# Altium schematic sheet boost_converter_var2.SchDoc(boost_converter_var2)
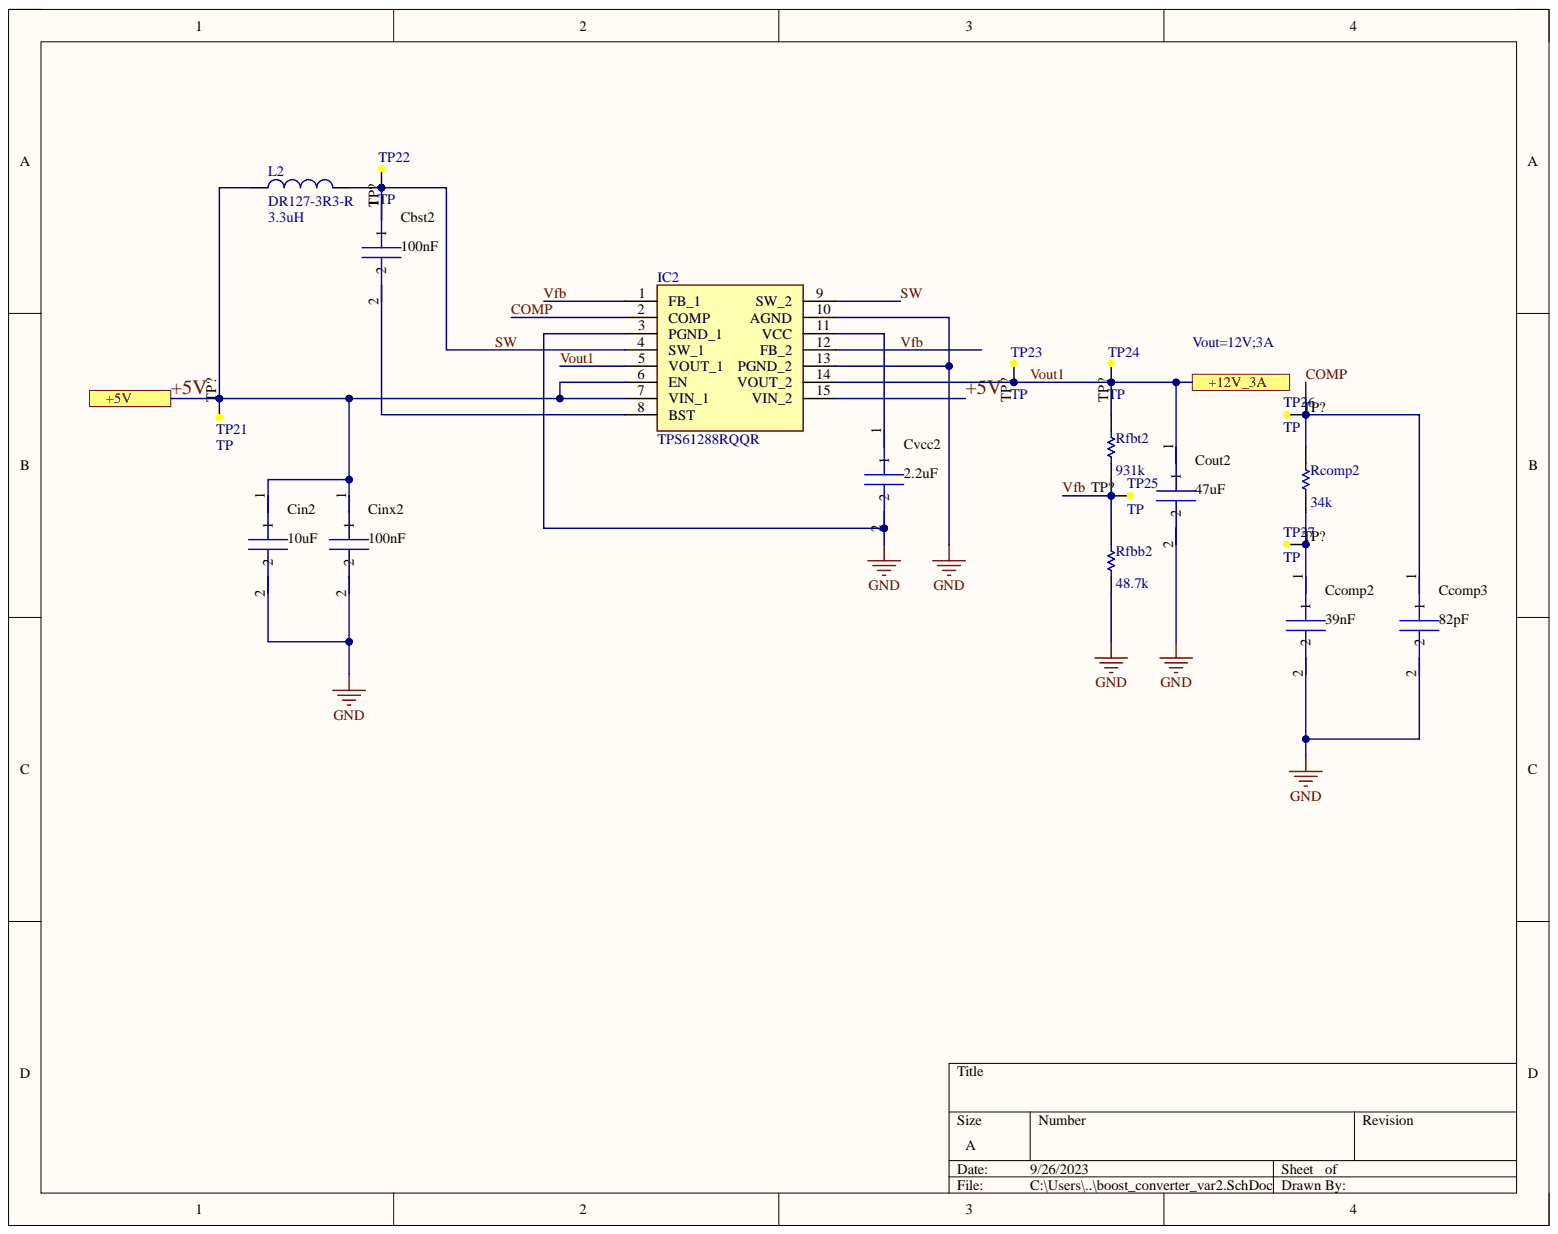
[diagram p0: boost_converter_var2.SchDoc]

## Parts
Cbst2  value 100nF  [CAPC1005X55N]  (hidden comment: EMK105 B7104KVHF)
Ccomp2  value 39nF  [CAPC0603X33N]  (hidden comment: GCM155R71E333K)
Ccomp3  value 82pF  [CAPC1005X55N]  (hidden comment: GCM1555C1H820J*)
Cin2  value 10uF  [CAPC2012X145N]  (hidden comment: C2012X7S1C106K)
Cinx2  value 100nF  [CAPC1005X55N]  (hidden comment: EMK105 B7104KVHF)
Cout2  value 47uF  [CAPC3225X270N]  (hidden comment: CKG57KX7R1E476K)
Cvcc2  value 2.2uF  [CAPC1608X80N]  (hidden comment: GCM188C71A225K)
IC2  TPS61288RQQR  [TPS61288RQQR]
L2  DR127-3R3-R  value 3.3uH  [INDPM125125X800N]
Rcomp2  value 34k  [RESC1608X55N]  (hidden comment: SMM0204 50 34K 1 %)
Rfbb2  value 48.7k  [SMM02040D1501BB300]  (hidden comment: SMM0204 50 48K7 1 %)
Rfbt2  value 931k  [RESC1608X55N]  (hidden comment: SMM0204 50 931K 1 %)
TP21  TP  [Test_Point0.5x0.5]
TP22  TP  [Test_Point0.5x0.5]
TP23  TP  [Test_Point0.5x0.5]
TP24  TP  [Test_Point0.5x0.5]
TP25  TP  [Test_Point0.5x0.5]
TP26  TP  [Test_Point0.5x0.5]
TP27  TP  [Test_Point0.5x0.5]

## Ports
+5V, +12V_3A

## Nets
GND: Ccomp2.2 Ccomp3.2 Cin2.2 Cinx2.2 Cout2.2 Cvcc2.2 IC2.3(PGND_1) IC2.10(AGND) IC2.13(PGND_2) Rfbb2.1
+5V: Cin2.1 Cinx2.1 IC2.6(EN) IC2.7(VIN_1) IC2.15(VIN_2) L2.1 TP21.TP? ; port +5V
COMP: Ccomp3.1 IC2.2(COMP) Rcomp2.2 TP26.TP?
SW: Cbst2.1 IC2.4(SW_1) IC2.9(SW_2) L2.2 TP22.TP?
Vfb: IC2.1(FB_1) IC2.12(FB_2) Rfbb2.2 Rfbt2.1 TP25.TP?
Vout1: Cout2.1 IC2.5(VOUT_1) IC2.14(VOUT_2) Rfbt2.2 TP23.TP? TP24.TP? ; port +12V_3A
NetCbst2_2: Cbst2.2 IC2.8(BST)
NetCcomp2_1: Ccomp2.1 Rcomp2.1 TP27.TP?
NetCvcc2_1: Cvcc2.1 IC2.11(VCC)

## Library data of the parts
Cbst2 Ccomp2 Ccomp3 Cin2 Cinx2 Cout2 Cvcc2 = C3216X6S1V106K160AC: C3216X6S1V106K16; Manufacturer Name: TDK; Component Kind: Standard; Library Name: TI WEBENCH; Manufacturer Part Number: C3216X6S1V106K160AC; PackageReference: C3216X6S1V106K160AC
IC2 = TPS61288RQQR: Integrated Circuit; Manufacturer_Name: Texas Instruments; Arrow Part Number: TPS61288RQQR; Arrow Price/Stock: https://www.arrow.com/en/products/tps61288rqqr/texas-instruments?region=nac; Component Kind: Standard; Datasheet Link: https://www.ti.com/lit/ds/symlink/tps61288.pdf?ts=1609351344517&ref_url=https%253A%252F%252Fwww.ti.com%252Fpower-management%252Fnon-isolated-dc-dc-switching-regulators%252Fstep-up-boost%252Fboost-converters-integrated-switch%252Fproducts.html; Height: 1mm; Library Name: TPS62188RQQ.SchLib; Manufacturer_Part_Number: TPS61288RQQR; Mouser Part Number: 595-TPS61288RQQR; Mouser Price/Stock: https://www.mouser.co.uk/ProductDetail/Texas-Instruments/TPS61288RQQR?qs=eP2BKZSCXI7ZX8saYJkaIw%3D%3D
L2 = Inductor: Code_IEC: 1005; Code_JEITA: 0402; Component Kind: Standard; Description: Inductor; LatestRevisionDate: 29-May-2009; LatestRevisionNote: IPC-7351 Footprint Added.; Library Name: Miscellaneous Devices.IntLib; PackageDescription: Chip Inductor; PackageReference: 0402-A; Published: 8-Jun-2000; Signal Integrity: Inductor; Simulation: INDUCTOR; Publisher: Altium Limited
Rcomp2 Rfbb2 Rfbt2 = Res1: Resistor; Component Kind: Standard; LatestRevisionDate: 17-Jul-2002; LatestRevisionNote: Re-released for DXP Platform.; Library Name: Miscellaneous Devices.IntLib; PackageDescription: Axial Device, Thru-Hole; 2 Leads; 0.3 in Pin Spacing; PackageReference: AXIAL-0.3; Published: 8-Jun-2000; Signal Integrity: Res; Simulation: RESISTOR; Publisher: Altium Limited
TP21-TP27 = TEst_Point_0.5x0.5: Component Kind: Standard; Library Name: TP_0.6x0.6.SchLib
